# Altium schematic sheet MOSFET.SchDoc
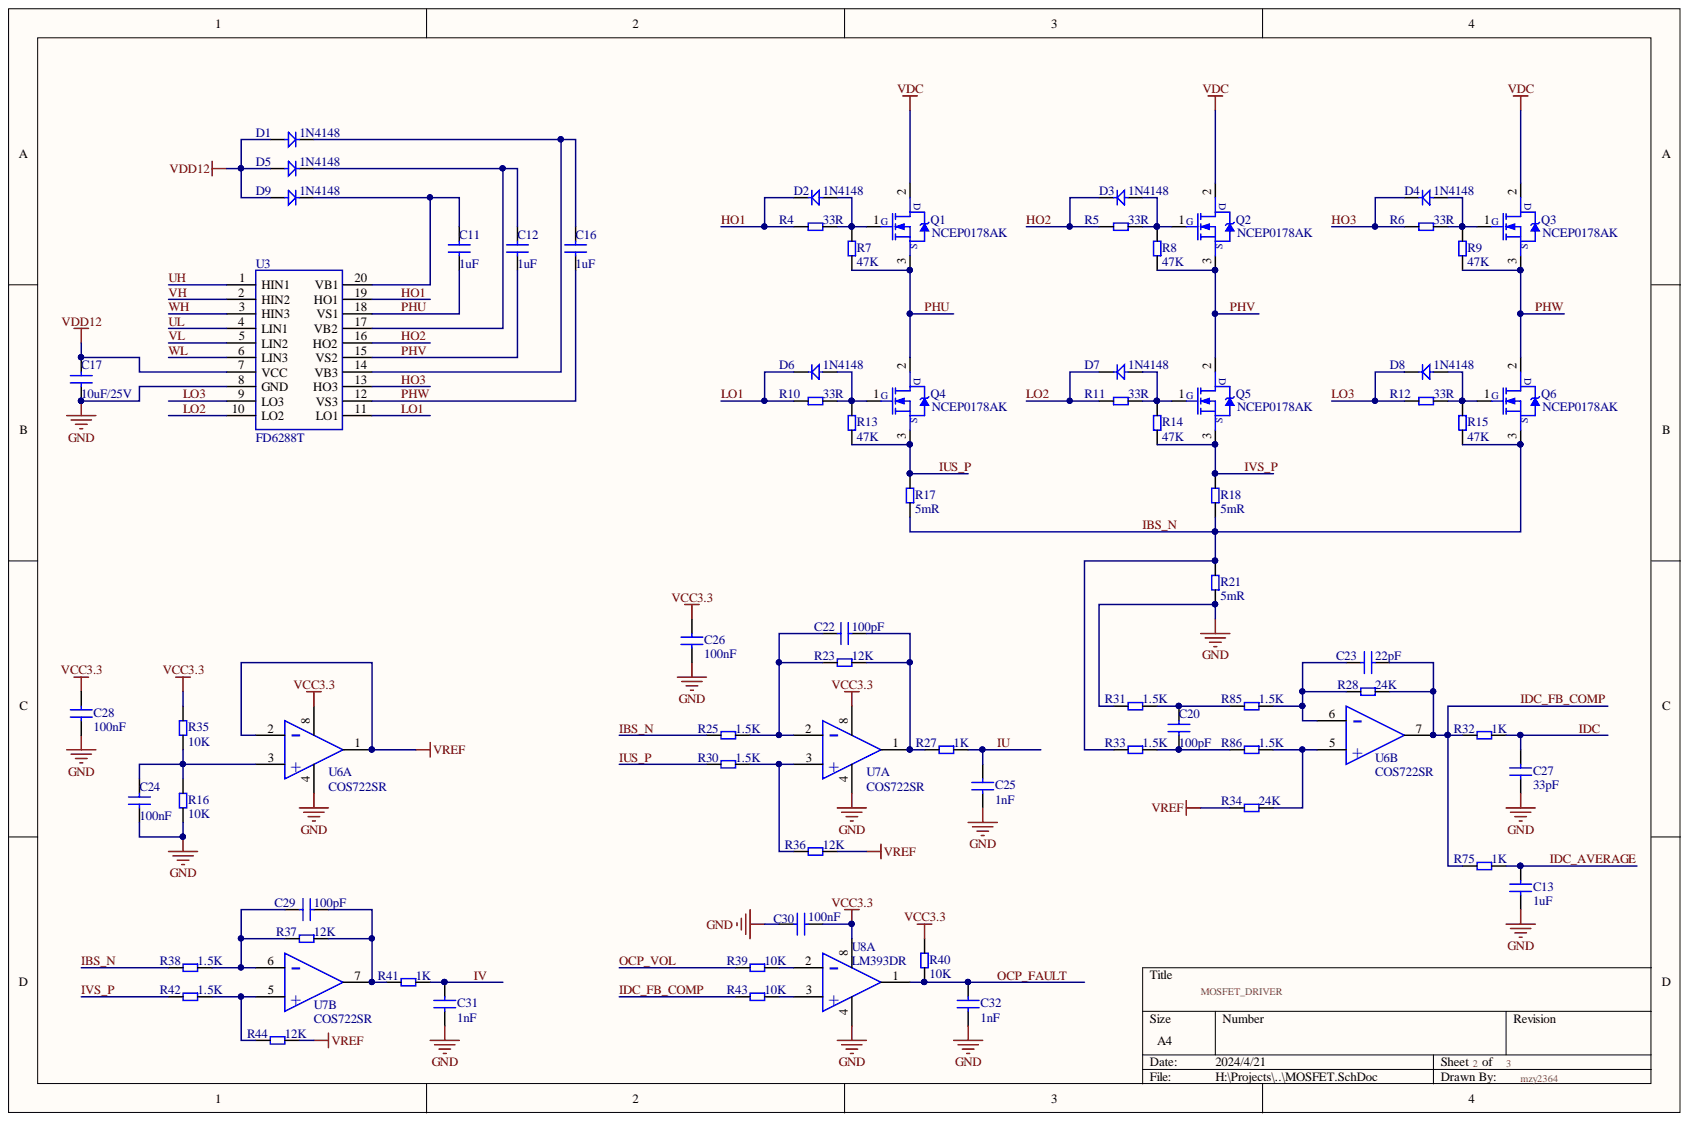
[diagram p0: MOSFET.SchDoc]

## Parts
C11  1uF  [C0603]
C12  1uF  [C0603]
C13  1uF  [C0603]
C16  1uF  [C0603]
C17  10uF/25V  [C0805]
C20  100pF  [C0603]
C22  100pF  [C0603]
C23  22pF  [C0603]
C24  100nF  [C0603]
C25  1nF  [C0603]
C26  100nF  [C0603]
C27  33pF  [C0603]
C28  100nF  [C0603]
C29  100pF  [C0603]
C30  100nF  [C0603]
C31  1nF  [C0603]
C32  1nF  [C0603]
D1  1N4148  [SOD323]
D2  1N4148  [SOD323]
D3  1N4148  [SOD323]
D4  1N4148  [SOD323]
D5  1N4148  [SOD323]
D6  1N4148  [SOD323]
D7  1N4148  [SOD323]
D8  1N4148  [SOD323]
D9  1N4148  [SOD323]
Q1  NCEP0178AK  [TO252]
Q2  NCEP0178AK  [TO252]
Q3  NCEP0178AK  [TO252]
Q4  NCEP0178AK  [TO252]
Q5  NCEP0178AK  [TO252]
Q6  NCEP0178AK  [TO252]
R4  33R  [RES0603]
R5  33R  [RES0603]
R6  33R  [RES0603]
R7  47K  [RES0603]
R8  47K  [RES0603]
R9  47K  [RES0603]
R10  33R  [RES0603]
R11  33R  [RES0603]
R12  33R  [RES0603]
R13  47K  [RES0603]
R14  47K  [RES0603]
R15  47K  [RES0603]
R16  10K  [RES0603]
R17  5mR  [RES2512]
R18  5mR  [RES2512]
R21  5mR  [RES2512]
R23  12K  [RES0603]
R25  1.5K  [RES0603]
R27  1K  [RES0603]
R28  24K  [RES0603]
R30  1.5K  [RES0603]
R31  1.5K  [RES0603]
R32  1K  [RES0603]
R33  1.5K  [RES0603]
R34  24K  [RES0603]
R35  10K  [RES0603]
R36  12K  [RES0603]
R37  12K  [RES0603]
R38  1.5K  [RES0603]
R39  10K  [RES0603]
R40  10K  [RES0603]
R41  1K  [RES0603]
R42  1.5K  [RES0603]
R43  10K  [RES0603]
R44  12K  [RES0603]
R75  1K  [RES0603]
R85  1.5K  [RES0603]
R86  1.5K  [RES0603]
U3  FD6288T  [TSSOP20]
U6  COS722SR  [SOP8]  (parts A,B)
U7  COS722SR  [SOP8]  (parts A,B)
U8  LM393DR  [SOP8]  (part A)

## Nets
GND: C13.1 C17.1 C24.1 C25.1 C26.1 C27.1 C28.1 C30.2 C31.1 C32.1 R16.2 R21.1 R31.2 U3.8(GND) U6.4 U7.4 U8.4
VCC3.3: C26.2 C28.2 C30.1 R35.1 R40.1 U6.8 U7.8 U8.8
VDC: Q1.2 Q2.2 Q3.2
VDD12: C17.2 D1.1 D5.1 D9.1 U3.7(VCC)
VREF: R34.2 R36.1 R44.1 U6.1 U6.2(-)
HO1: D2.2 R4.1 U3.19(HO1)
HO2: D3.2 R5.1 U3.16(HO2)
HO3: D4.2 R6.1 U3.13(HO3)
IBS_N: Q6.3 R15.1 R17.1 R18.1 R21.2 R25.2 R33.2 R38.2
IDC: C27.2 R32.1
IDC_AVERAGE: C13.2 R75.1
IDC_FB_COMP: C23.1 R28.1 R32.2 R43.2 R75.2 U6.7
IU: C25.2 R27.1
IUS_P: Q4.3 R13.1 R17.2 R30.2
IV: C31.2 R41.1
IVS_P: Q5.3 R14.1 R18.2 R42.2
LO1: D6.2 R10.1 U3.11(LO1)
LO2: D7.2 R11.1 U3.10(LO2)
LO3: D8.2 R12.1 U3.9(LO3)
OCP_FAULT: C32.2 R40.2 U8.1
OCP_VOL: R39.2
PHU: C11.1 Q1.3 Q4.2 R7.1 U3.18(VS1)
PHV: C12.1 Q2.3 Q5.2 R8.1 U3.15(VS2)
PHW: C16.1 Q3.3 Q6.2 R9.1 U3.12(VS3)
UH: U3.1(HIN1)
UL: U3.4(LIN1)
VH: U3.2(HIN2)
VL: U3.5(LIN2)
WH: U3.3(HIN3)
WL: U3.6(LIN3)
NetC11_2: C11.2 D9.2 U3.20(VB1)
NetC12_2: C12.2 D5.2 U3.17(VB2)
NetC16_2: C16.2 D1.2 U3.14(VB3)
NetC20_1: C20.1 R31.1 R85.2
NetC20_2: C20.2 R33.1 R86.2
NetC22_1: C22.1 R23.1 R27.2 U7.1
NetC22_2: C22.2 R23.2 R25.1 U7.2(-)
NetC23_2: C23.2 R28.2 R85.1 U6.6(-)
NetC24_2: C24.2 R16.1 R35.2 U6.3(+)
NetC29_1: C29.1 R37.1 R41.2 U7.7
NetC29_2: C29.2 R37.2 R38.1 U7.6(-)
NetD2_1: D2.1 Q1.1 R4.2 R7.2
NetD3_1: D3.1 Q2.1 R5.2 R8.2
NetD4_1: D4.1 Q3.1 R6.2 R9.2
NetD6_1: D6.1 Q4.1 R10.2 R13.2
NetD7_1: D7.1 Q5.1 R11.2 R14.2
NetD8_1: D8.1 Q6.1 R12.2 R15.2
NetR30_1: R30.1 R36.2 U7.3(+)
NetR34_1: R34.1 R86.1 U6.5(+)
NetR39_1: R39.1 U8.2(-)
NetR42_1: R42.1 R44.2 U7.5(+)
NetR43_1: R43.1 U8.3(+)

## Library data of the parts
C11-C13 C16 C17 C20 C22-C32 = CAP: Material: X7R; Precision: 10%; Voltage: 50V; Component Kind: Standard; Library Name: mzy2364.SchLib
D1-D9 = D: Component Kind: Standard; Library Name: mzy2364.SchLib
Q1-Q6 = NMOS-TO252: Component Kind: Standard; Library Name: mzy2364.SchLib
R4-R18 R21 R23 R25 R27 R28 R30-R44 R75 R85 R86 = RES: Component Kind: Standard; Library Name: mzy2364.SchLib
U3 = EG2134: Component Kind: Standard; Library Name: mzy2364.SchLib
U6-U8 = AD8656: Component Kind: Standard; Library Name: mzy2364.SchLib
